# Altium schematic sheet DRV8844.SchDoc
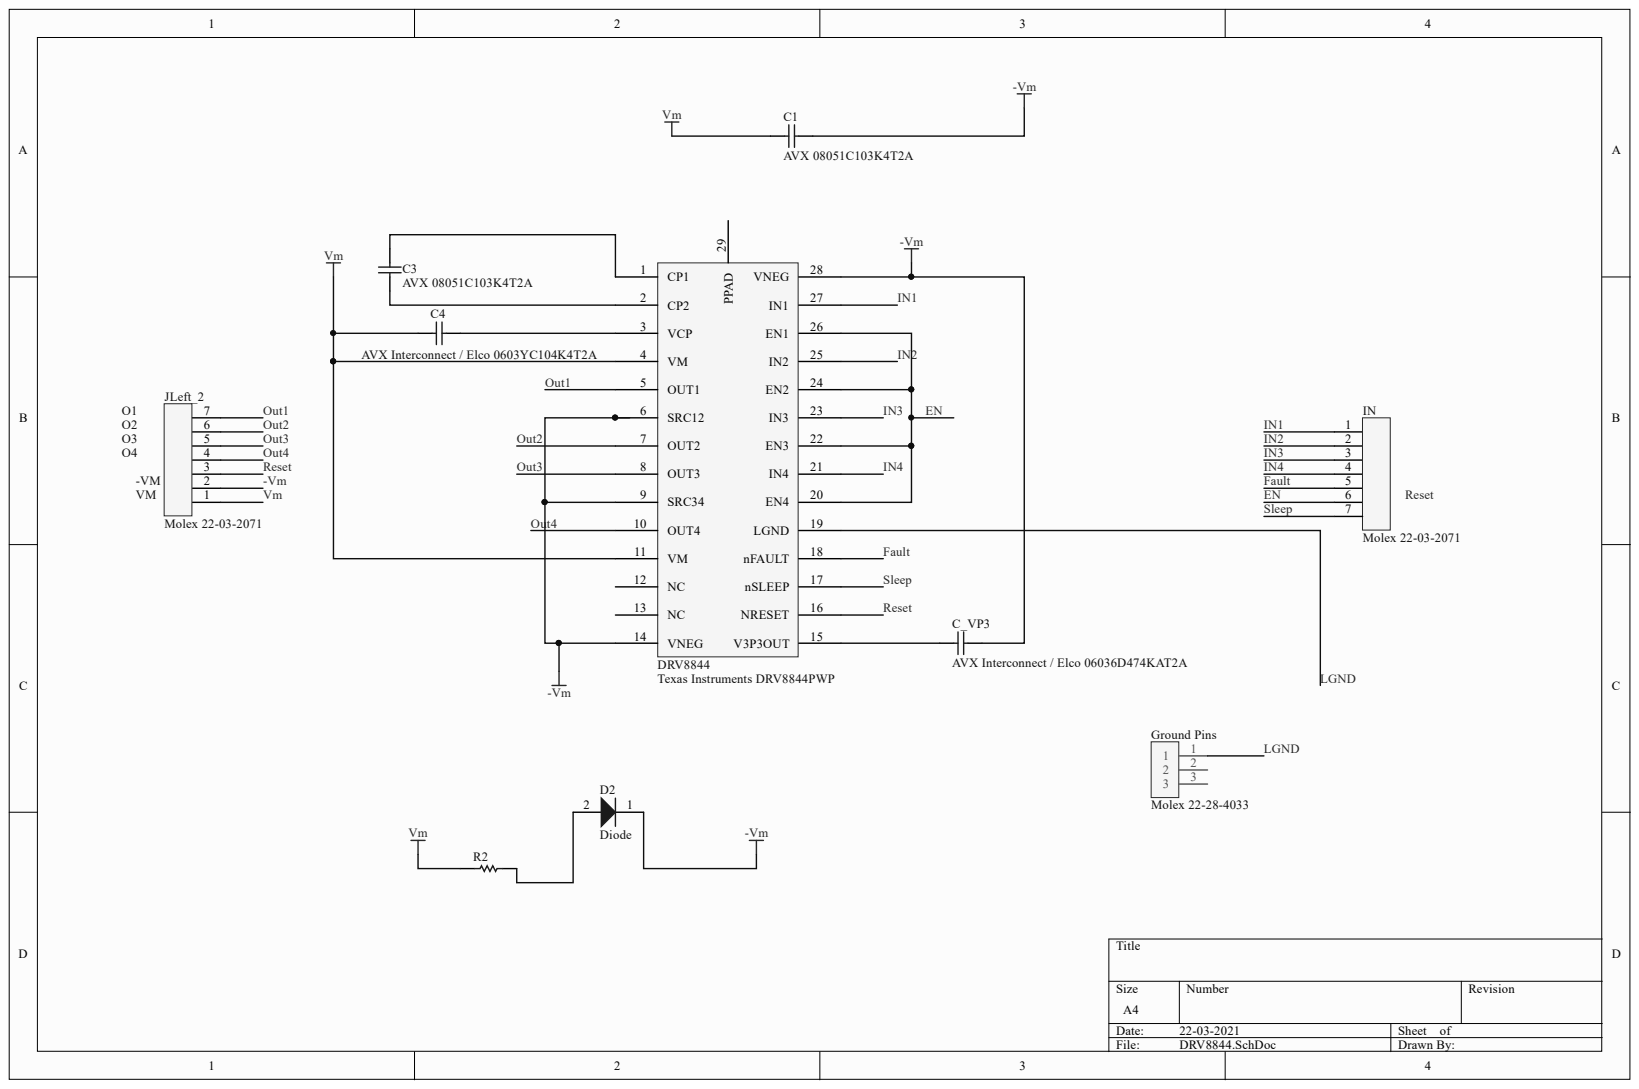
[diagram p0: DRV8844.SchDoc]

## Parts
C1  AVX 08051C103K4T2A  Manufacturer Part Number: 08051C103K4T2A  [PCB-yxmcrvfmg46l6n035uvh-1]
C3  AVX 08051C103K4T2A  [PCB-yxmcrvfmg46l6n035uvh-1]
C4  AVX Interconnect / Elco 0603YC104K4T2A  [CAPC1608X90X35LL15T15]
C_VP3  AVX Interconnect / Elco 06036D474KAT2A  [CAPC1608X90X35LL15T15]
D2  Diode  [PCB-7kukp7vedbed875dt9uo-1]
DRV8844  Texas Instruments DRV8844PWP  Manufacturer Part Number: DRV8844PWP  [PCB-0xtv3mcdbyxsg04d7lcl-1]
Ground_Pins  Molex 22-28-4033  Manufacturer Part Number: 22-28-4033  [PCB-8kup8qw5vsmkearo8v0b-1]
IN  Molex 22-03-2071  Manufacturer Part Number: 22-03-2071  [PCB-z56b2rsb9v6v32i09oxr-1]
JLeft_2  Molex 22-03-2071  [PCB-z56b2rsb9v6v32i09oxr-1]
R2  Panasonic ERJ-3GEYJ332V  [RESC1608X55X30LL15T15]  (value not drawn)

## Nets
-VM: C1.1 C_VP3.2 D2.1 DRV8844.6(SRC12) DRV8844.9(SRC34) DRV8844.14(VNEG) DRV8844.28(VNEG) JLeft_2.2
EN: DRV8844.20(EN4) DRV8844.22(EN3) DRV8844.24(EN2) DRV8844.26(EN1) IN.6
FAULT: DRV8844.18(nFAULT) IN.5
IN1: DRV8844.27(IN1) IN.1
IN2: DRV8844.25(IN2) IN.2
IN3: DRV8844.23(IN3) IN.3
IN4: DRV8844.21(IN4) IN.4
LGND: DRV8844.19(LGND) Ground_Pins.1
OUT1: DRV8844.5(OUT1) JLeft_2.7
OUT2: DRV8844.7(OUT2) JLeft_2.6
OUT3: DRV8844.8(OUT3) JLeft_2.5
OUT4: DRV8844.10(OUT4) JLeft_2.4
RESET: DRV8844.16(NRESET) JLeft_2.3
SLEEP: DRV8844.17(nSLEEP) IN.7
VM: C1.2 C4.2 DRV8844.4(VM) DRV8844.11(VM) JLeft_2.1 R2.1
NetC3_1: C3.1 DRV8844.1(CP1)
NetC3_2: C3.2 DRV8844.2(CP2)
NetC4_1: C4.1 DRV8844.3(VCP)
NetC_VP3_1: C_VP3.1 DRV8844.15(V3P3OUT)
NetD2_2: D2.2 R2.2

Not connected: DRV8844 12(NC) 13(NC) 29(PPAD); Ground_Pins 2 3

## Library data of the parts
C1 C3 = CMP-126400-3: 0805 10nF 100V ±10 % Tol. X7R Surface Mount Multilayer Ceramic Capacitor; Capacitance Tolerance: ±10%; Case/Package (SI): 2012; Lead-Free Status: Lead Free; Case/Package: 0805; Component Kind: Standard; Dielectric Characteristic: X7R; Library Name: CommunityVault; Number of Pins: 2; OctopartID: c3010a760a371cc6; Octopart URL: https://octopart.com/08051c103k4t2a-avx-8252496; Operating Temperature: -55.0 °C (min); Packaging: Cut Tape (CT), Tape & Reel (TR); REACH SVHC Compliance: No SVHC; RoHS: Compliant; Voltage Rating (DC): 100 V
  C3: Manufacturer Part Number: 08051C103K4T2A
C4 = CMP-175023-2: Cap Ceramic 0.1uF 16V X7R 10% SMD 0603 125C Paper T/R; Capacitance: 1e-07 F; Lead-Free Status: Lead Free; Voltage Rating (DC): 16.0 V; Capacitance Tolerance: ±10%; Case/Package: 0603; Component Kind: Standard; Dielectric Characteristic: X7R; Library Name: CommunityVault; Manufacturer Part Number: 0603YC104K4T2A; Mounting Style: Surface Mount; Number of Pins: 2; OctopartID: 8dfd448c41d0523a; Octopart Url: https://octopart.com/0603yc104k4t2a-avx+interconnect+%2F+elco-8120651; Packaging: Tape; REACH SVHC Compliance: No SVHC; RoHS: Compliant
C_VP3 = CMP-176977-2: CAP CER 0.47UF 6.3V 10% X5R 0603; Capacitance: 4.7e-07 F; Lead-Free Status: Lead Free; Capacitance Tolerance: ±10%; Case/Package: 0603; Component Kind: Standard; Dielectric Characteristic: X5R; Library Name: CommunityVault; Manufacturer Part Number: 06036D474KAT2A; Mounting Style: Surface Mount; Number of Pins: 2; OctopartID: 0428cda2c203dbef; Octopart Url: https://octopart.com/06036d474kat2a-avx+interconnect+%2F+elco-8446797; Packaging: Tape; RoHS: Compliant; Voltage Rating (DC): 6.3 V
D2 = CMP-55059cc3e5848ac2-1: Rectifier Diode 1300V 3A DO201AD THT; Manufacturer Part Number: 1N5404; Capacitance: 30.0 pF; Current Rating: 3.00 A; Forward Voltage: 1.20 V; Lead-Free Status: Lead Free; Output Current: 3.00 A (max); Polarity: Standard; Voltage Rating (DC): 400 V; Case/Package: DO-201; Component Kind: Standard; Library Name: CommunityVault; Lifecycle Status: Active; Mounting Style: Through Hole; Number of Pins: 2; OctopartID: 55059cc3e5848ac2; Octopart URL: http://octopart.com/1n5404-fairchild+semiconductor-13407719; Packaging: Tape; REACH SVHC Compliance: No SVHC; RoHS: Compliant
DRV8844 = CMP-e907217fead636c0-2: 5A Brushed DC motor or Quad 1.75A Solenoid/Relay Driver with +/-30V split supply support (PWM Ctrl) 28-HTSSOP -40 to 85; Lead-Free Status: Lead Free; Case/Package: HTSSOP; Component Kind: Standard; Library Name: CommunityVault; Lifecycle Status: Active; Mounting Style: Surface Mount; Number of Pins: 28; OctopartID: e907217fead636c0; Octopart URL: https://octopart.com/drv8844pwp-texas+instruments-24258231; Operating Temperature: -40.0 °C to 85.0 °C; Packaging: Tube; RoHS: Compliant
Ground_Pins = CMP-b995709ba24d6652-18: SERVAL TRIJUMPER; Current Rating: 4.00 A; Gender: Male; Glow Wire Compliant: Non-Compliant; Number of Circuits: 3; Pin Pitch: 2.54 mm; Voltage Rating (DC): 250 V; Component Kind: Standard; Contact Material: Phosphor Bronze; Contact Plating: Gold; Flammability Rating: UL 94 V-0; Halogen Free Status: Not Low Halogen; Housing Color: Black; Housing Material: Nylon; Library Name: CommunityVault; Mounting Style: Through Hole; Number of Positions: 3; Number of Rows: 1; OctopartID: b995709ba24d6652; Octopart URL: https://octopart.com/22-28-4033-molex-278206; Operating Temperature: 75.0 °C (max); Orientation: Vertical; Packaging: Bulk; REACH SVHC Compliance: No SVHC; RoHS: Compliant; Size-Height: 2.29 mm; Size-Length: 7.62 mm
IN = CMP-da4b1345c3c3058c-6: Connector Unshrouded Header 7 Position 2.54mm Solder Straight Thru-Hole Bag; Glow Wire Compliant: Non-Compliant; Insulation Resistance: 50.0 GΩ; Number of Circuits: 7, 6; Number of Positions: 7; Voltage Rating (DC): 250 V; Component Kind: Standard; Contact Plating: Tin; Halogen Free Status: Not Reviewed; Library Name: CommunityVault; Number of Rows: 1; OctopartID: da4b1345c3c3058c; Operating Temperature: 105 °C (max); Packaging: Bulk; Pin Pitch: 2.54 mm; RoHS: Compliant; Size-Length: 17.5 mm
JLeft_2 = CMP-da4b1345c3c3058c-1: Connector Unshrouded Header 7 Position 2.54mm Solder Straight Thru-Hole Bag; Glow Wire Compliant: Non-Compliant; Insulation Resistance: 50.0 GΩ; Lead-Free Status: Lead Free; Number of Circuits: 7, 6; Number of Contacts: 7; Voltage Rating (DC): 250 V; Component Kind: Standard; Flammability Rating: UL 94 V-0; Halogen Free Status: Not Reviewed; Housing Color: White; Library Name: CommunityVault; Lifecycle Status: Active; Number of Positions: 7; Number of Rows: 1; OctopartID: da4b1345c3c3058c; Operating Temperature: 105 °C (max); Packaging: Bulk; Pin Pitch: 2.54 mm; RoHS: Compliant; Size-Height: 3.30 mm; Size-Length: 17.5 mm
R2 = CMP-3656259-2: 3.3k; Lead-Free Status: Lead Free; Power Rating: 0.1 W; Resistance: 3300.0 Ω; Resistance Tolerance: ±5%; Voltage Rating (DC): 75.0 V; Case/Package: 0603; Component Kind: Standard; Library Name: CommunityVault; Lifecycle Status: Not Listed by Manufacturer; Manufacturer Part Number: ERJ-3GEYJ332V; Mounting Style: Surface Mount; OctopartID: f2c038877b272d07; Octopart Url: https://octopart.com/erj-3geyj332v-panasonic-55422081; Packaging: Cut Tape (CT); REACH SVHC Compliance: No SVHC; RoHS: Compliant; Size-Height: 0.00045 m; Size-Length: 0.0016 m; Size-Width: 0.0008 m
